# Altium schematic sheet Function.SchDoc(Function)
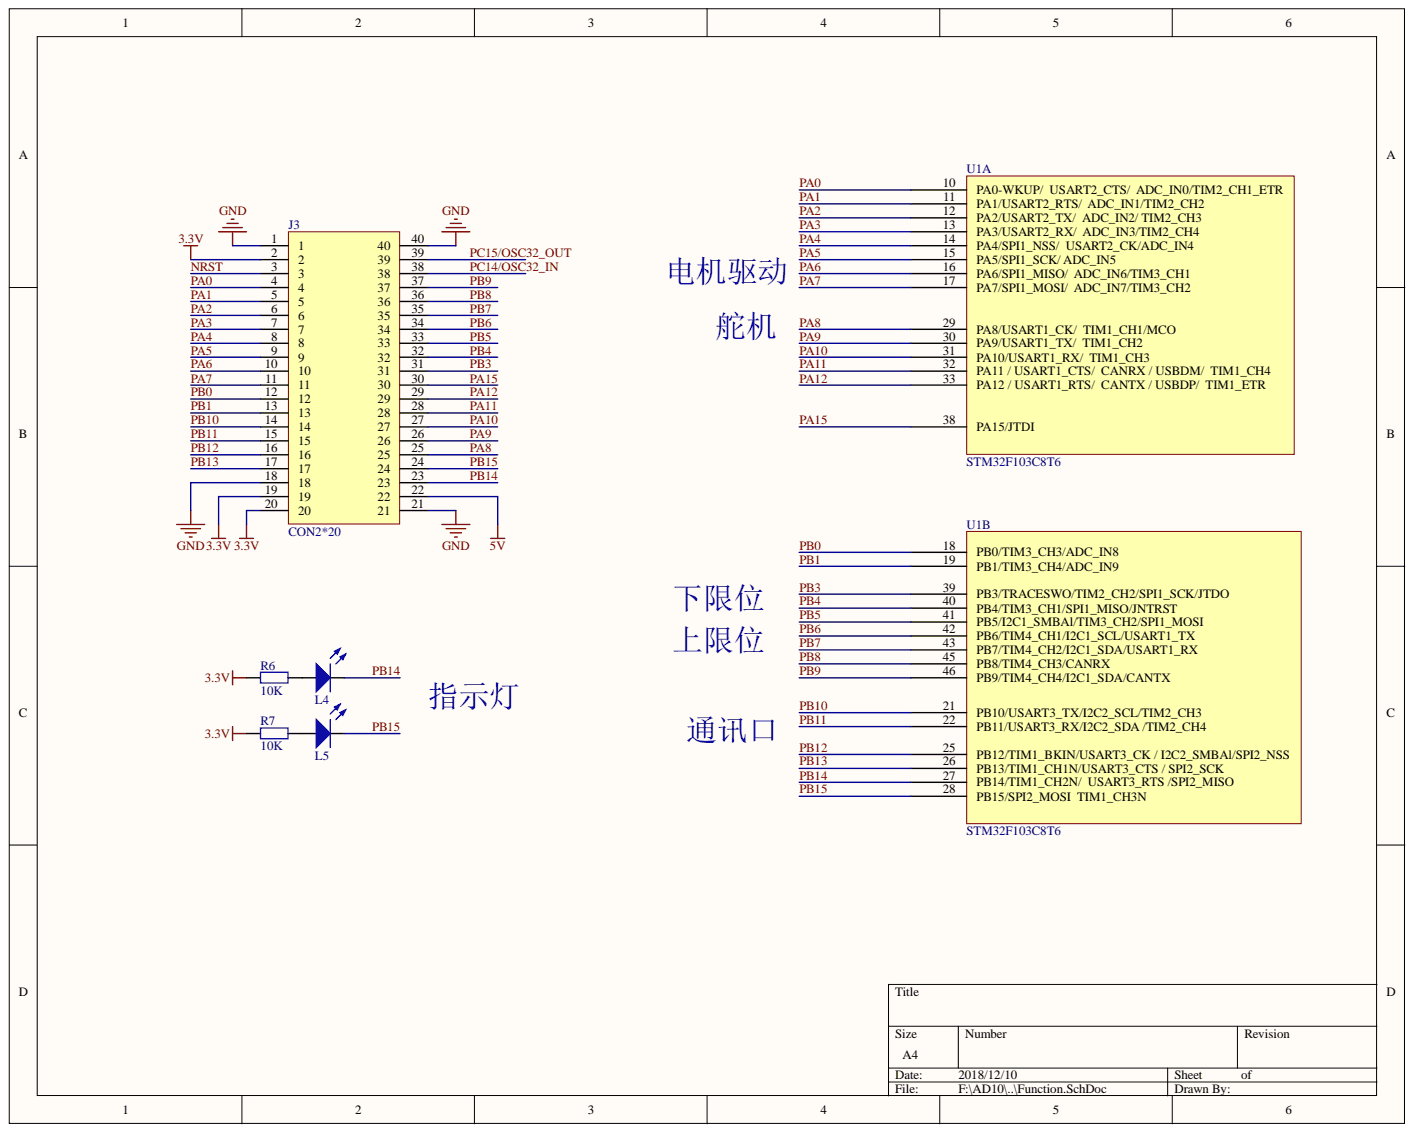
[diagram p0: Function.SchDoc]

## Parts
J3  CON2*20  [CON2*20]
L4  LED_0603_RED  [LC-0603_LED_S1]  (value not drawn)
L5  LED_0603_RED  [LC-0603_LED_S1]  (value not drawn)
R6  10K  [LC-0603_R]
R7  10K  [LC-0603_R]
U1  STM32F103C8T6  (parts A,B)

## Nets
3.3V: J3.2 J3.19 J3.20 R6.1 R7.1
5V: J3.22
GND: J3.1 J3.18 J3.21 J3.40
NRST: J3.3
PA0: J3.4 U1.10(PA0-WKUP/)
PA1: J3.5 U1.11(PA1/USART2_RTS/)
PA2: J3.6 U1.12(PA2/USART2_TX/)
PA3: J3.7 U1.13(PA3/USART2_RX/)
PA4: J3.8 U1.14(PA4/SPI1_NSS/)
PA5: J3.9 U1.15(PA5/SPI1_SCK/_ADC_IN5)
PA6: J3.10 U1.16(PA6/SPI1_MISO/)
PA7: J3.11 U1.17(PA7/SPI1_MOSI/)
PA8: J3.25 U1.29(PA8/USART1_CK/)
PA9: J3.26 U1.30(PA9/USART1_TX/)
PA10: J3.27 U1.31(PA10/USART1_RX/)
PA11: J3.28 U1.32(PA11_/_USART1_CTS/)
PA12: J3.29 U1.33(PA12_/_USART1_RTS/)
PA15: J3.30 U1.38(PA15/JTDI)
PB0: J3.12 U1.18(PB0/TIM3_CH3/ADC_IN8)
PB1: J3.13 U1.19(PB1/TIM3_CH4/ADC_IN9)
PB3: J3.31 U1.39(PB3/TRACESWO/TIM2_CH2/SPI1_SCK/JTDO)
PB4: J3.32 U1.40(PB4/TIM3_CH1/SPI1_MISO/JNTRST)
PB5: J3.33 U1.41(PB5/I2C1_SMBAl/TIM3_CH2/SPI1_MOSI)
PB6: J3.34 U1.42(PB6/TIM4_CH1/I2C1_SCL/USART1_TX)
PB7: J3.35 U1.43(PB7/TIM4_CH2/I2C1_SDA/USART1_RX)
PB8: J3.36 U1.45(PB8/TIM4_CH3/CANRX)
PB9: J3.37 U1.46(PB9/TIM4_CH4/I2C1_SDA/CANTX)
PB10: J3.14 U1.21(PB10/USART3_TX/I2C2_SCL/TIM2_CH3)
PB11: J3.15 U1.22(PB11/USART3_RX/I2C2_SDA_/TIM2_CH4)
PB12: J3.16 U1.25(PB12/TIM1_BKIN/USART3_CK_/_I2C2_SMBAl/SPI2_NSS)
PB13: J3.17 U1.26(PB13/TIM1_CH1N/USART3_CTS_/_SPI2_SCK)
PB14: J3.23 L4.2 U1.27(PB14/TIM1_CH2N/)
PB15: J3.24 L5.2 U1.28(PB15/SPI2_MOSI)
PC14/OSC32_IN: J3.38
PC15/OSC32_OUT: J3.39
NetL4_1: L4.1 R6.2
NetL5_1: L5.1 R7.2

## Library data of the parts
L4 L5 = LED_0603_RED: LED; Component Kind: Standard; Library Name: 工作原理库.SchLib
R6 R7 = Res_0603: Value: Res_0603; Description: Res_0603; Component Kind: Standard; Library Name: 工作原理库.SchLib
U1 = STM32F103C8T6_3: Component Kind: Standard; Library Name: 遗产.SchLib
J3 = CON2*20: Component Kind: Standard; Library Name: 工作原理库.SchLib
